# Altium schematic sheet HDMI_to_RGB.SchDoc
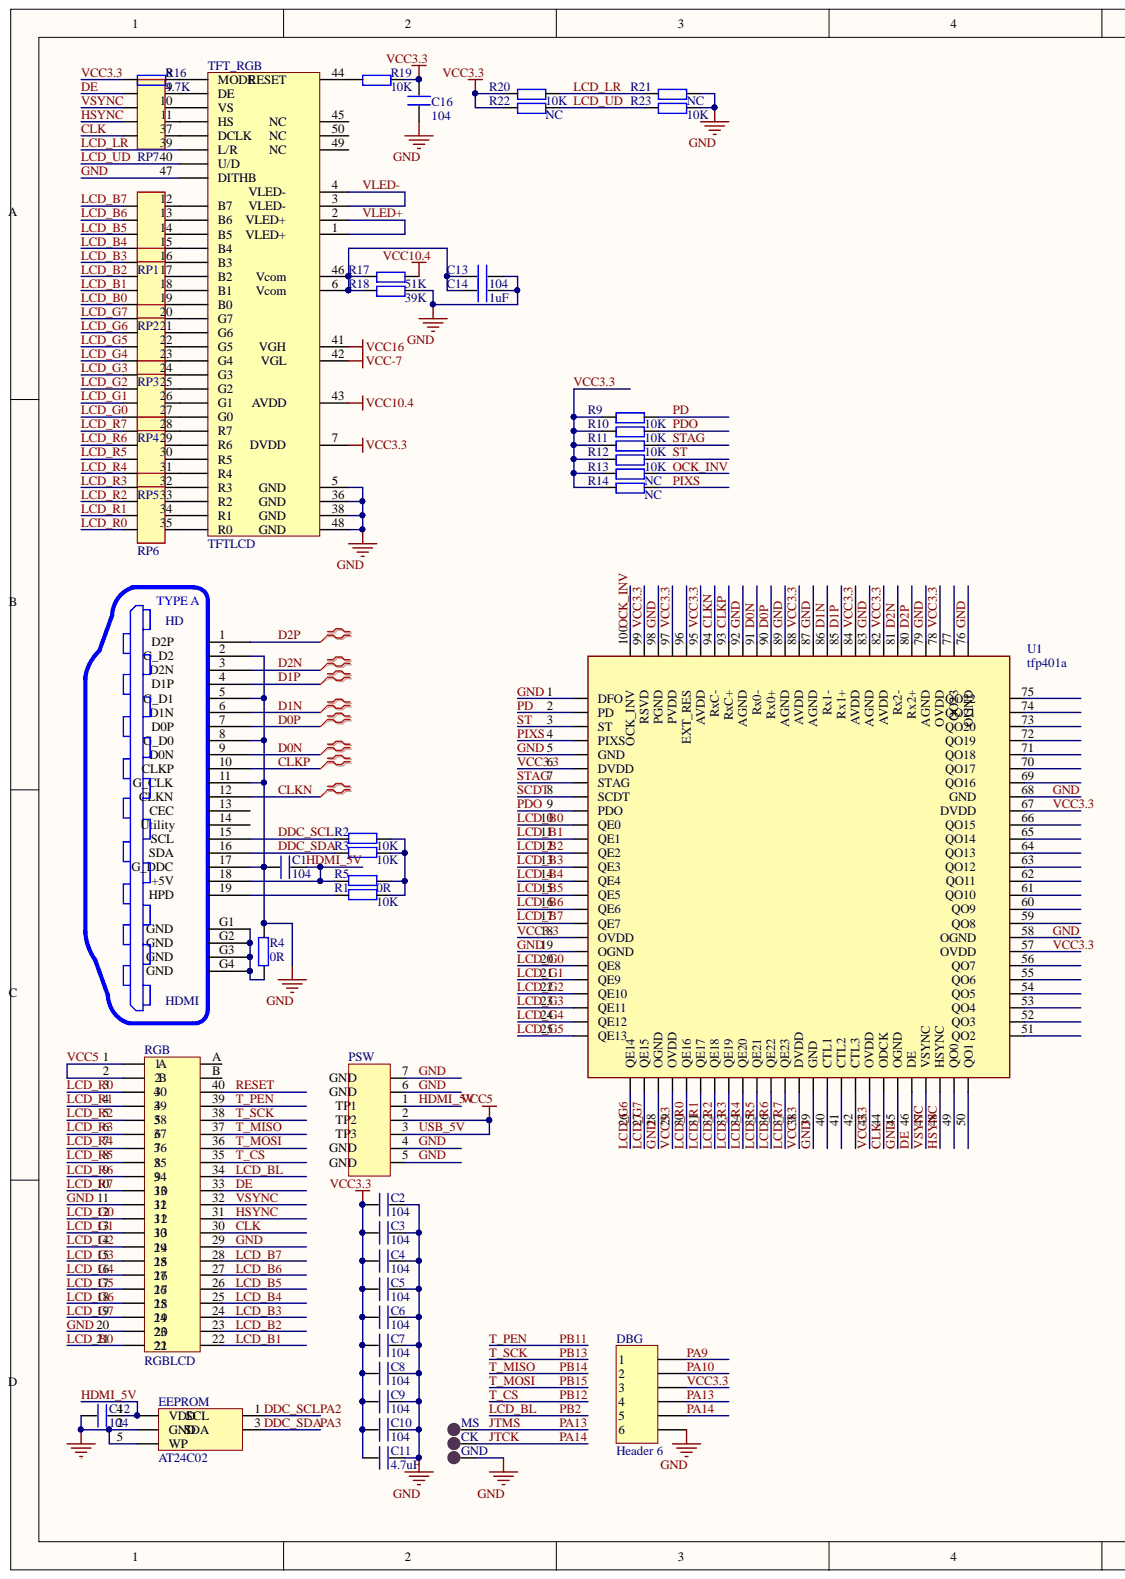
[diagram p0: HDMI_to_RGB.SchDoc, left]
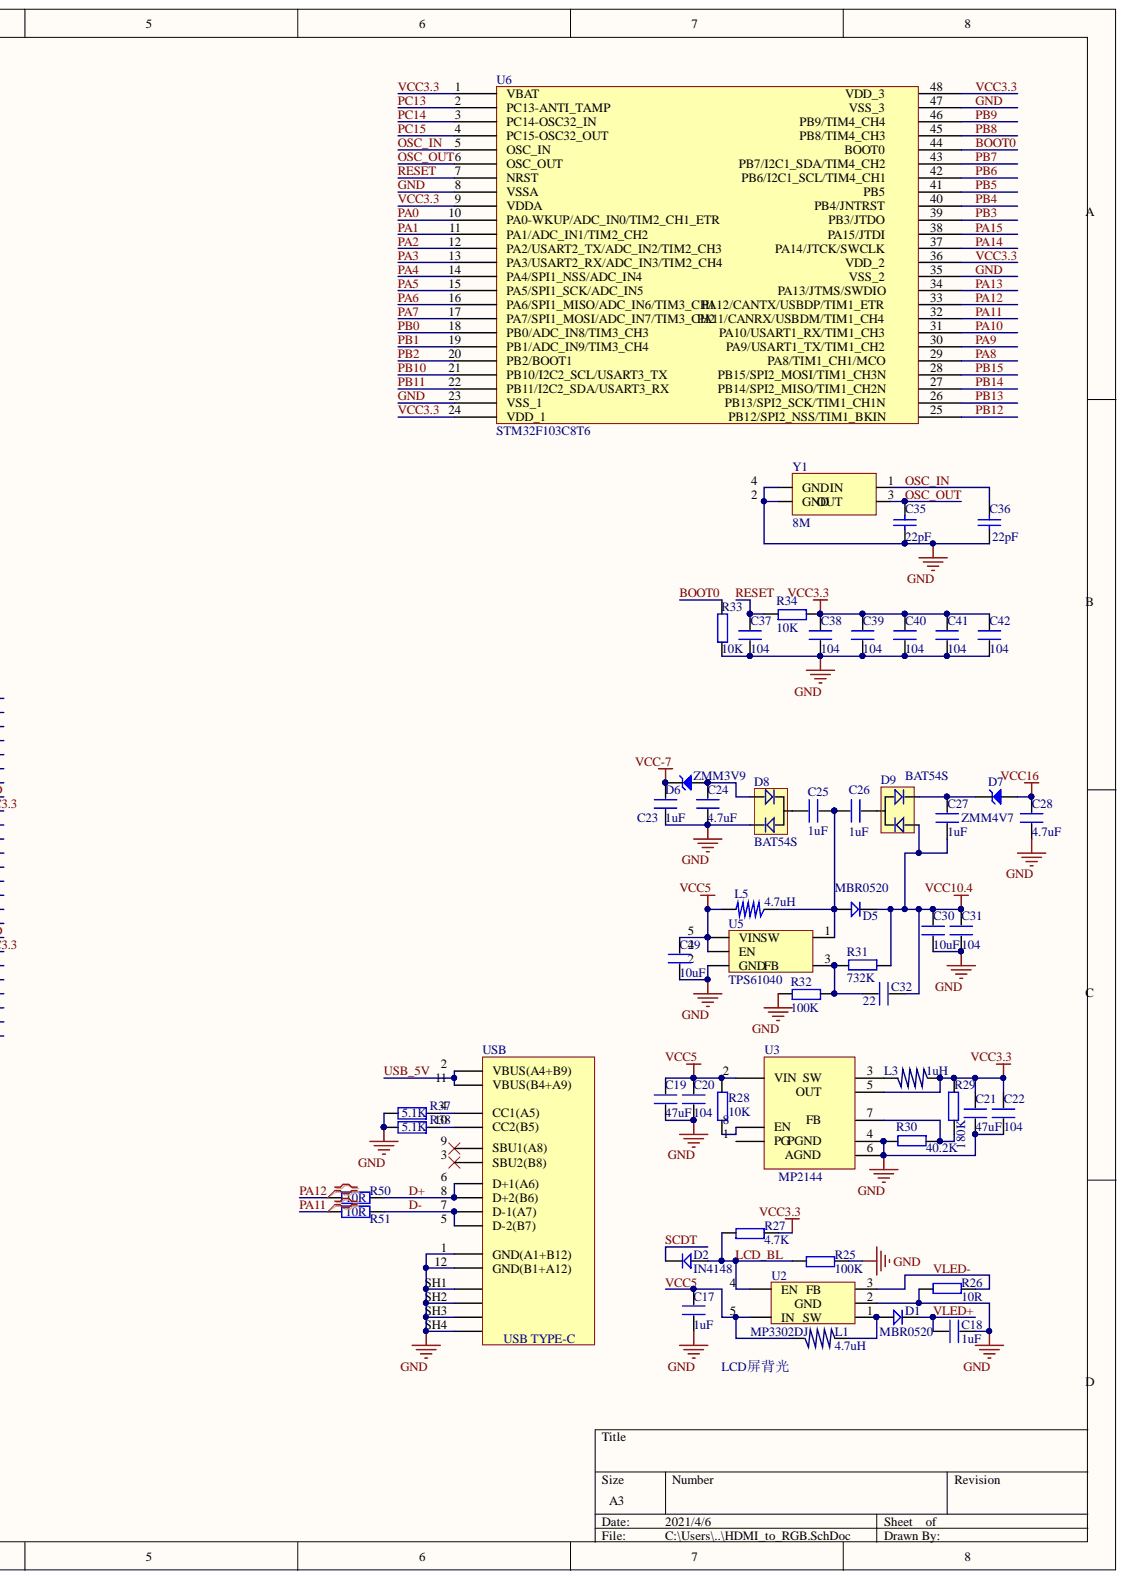
[diagram p1: HDMI_to_RGB.SchDoc, right]

## Parts
C1  104  [C 0603_L]
C2  104  [C 0603_L]
C3  104  [C 0603_L]
C4  104  [C 0603_L]
C5  104  [C 0603_L]
C6  104  [C 0603_L]
C7  104  [C 0603_L]
C8  104  [C 0603_L]
C9  104  [C 0603_L]
C10  104  [C 0603_L]
C11  4.7uF  [C 0603_L]
C12  104  [C 0603_L]
C13  104  [C 0603_L]
C14  1uF  [C 0603_L]
C16  104  [C 0603_L]
C17  1uF  [C 0603_L]
C18  1uF  [C 0603_L]
C19  47uF  [C 0805_L]
C20  104  [C 0603_L]
C21  47uF  [C 0805_L]
C22  104  [C 0603_L]
C23  1uF  [C 0603_L]
C24  4.7uF  [C 0603_L]
C25  1uF  [C 0603_L]
C26  1uF  [C 0603_L]
C27  1uF  [C 0603_L]
C28  4.7uF  [C 0603_L]
C29  10uF  [C 0603_L]
C30  10uF  [C 0603_L]
C31  104  [C 0603_L]
C32  22  [C 0603_L]
C35  22pF  [C 0603_L]
C36  22pF  [C 0603_L]
C37  104  [C 0603_L]
C38  104  [C 0603_L]
C39  104  [C 0603_L]
C40  104  [C 0603_L]
C41  104  [C 0603_L]
C42  104  [C 0603_L]
CK  TEST POINT  [TP-1.5]  (value not drawn)
D1  MBR0520  [SOD-123]
D2  IN4148  [SOD-123]
D5  MBR0520  [SOD-123]
D6  ZMM3V9  [MINI_MELF (LL34)]
D7  ZMM4V7  [MINI_MELF (LL34)]
D8  BAT54S  [SOT23-3L]
D9  BAT54S  [SOT23-3L]
DBG  Header 6  [MX 1.25-LI-6P]
EEPROM  AT24C02  [AT24C02]
GND  TEST POINT  [TP-1.5]  (value not drawn)
HD  HDMI  [HDMI_A]
L1  4.7uH  [NR4010]
L3  1uH  [NR3015]
L5  4.7uH  [NR4010]
MS  TEST POINT  [TP-1.5]  (value not drawn)
PSW  SWITCH  [SWITCH]  (value not drawn)
R1  10K  [R 0603_L]
R2  10K  [R 0603_L]
R3  10K  [R 0603_L]
R4  0R  [R 0603_L]
R5  0R  [R 0603_L]
R9  10K  [R 0603_L]
R10  10K  [R 0603_L]
R11  10K  [R 0603_L]
R12  10K  [R 0603_L]
R13  NC  [R 0603_L]
R14  NC  [R 0603_L]
R16  4.7K  [R 0603_L]
R17  51K  [R 0603_L]
R18  39K  [R 0603_L]
R19  10K  [R 0603_L]
R20  10K  [R 0603_L]
R21  NC  [R 0603_L]
R22  NC  [R 0603_L]
R23  10K  [R 0603_L]
R25  100K  [R 0603_L]
R26  10R  [R 0603_L]
R27  4.7K  [R 0603_L]
R28  10K  [R 0603_L]
R29  180K  [R 0603_L]
R30  40.2K  [R 0603_L]
R31  732K  [R 0603_L]
R32  100K  [R 0603_L]
R33  10K  [R 0603_L]
R34  10K  [R 0603_L]
R37  5.1K  [R 0603_L]
R38  5.1K  [R 0603_L]
R50  10R  [R 0603_L]
R51  10R  [R 0603_L]
RGB  RGBLCD  [FPC0.5 2H-WS-40P]
RP1  33R  [P_pack]  (value not drawn)
RP2  33R  [P_pack]  (value not drawn)
RP3  33R  [P_pack]  (value not drawn)
RP4  33R  [P_pack]  (value not drawn)
RP5  33R  [P_pack]  (value not drawn)
RP6  33R  [P_pack]  (value not drawn)
RP7  33R  [P_pack]  (value not drawn)
TFT_RGB  TFTLCD  [FPC-TOP50]
U1  tfp401a  [LQFP100]
U2  MP3302DJ  [SOT23-5L]
U3  MP2144  [SOT23-8L]
U5  TPS61040  [SOT23-5L]
U6  STM32F103C8T6  [LQFP48 7X7_L]
USB  USB TypeC  [USB Type-C]  (value not drawn)
Y1  8M  [OSC 3225-4P]

## Nets
VCC10.4: C27.1 C30.2 C31.1 C32.1 D5.2 D9.1 R17.2 R31.1 TFT_RGB.43
VCC16: C28.2 D7.1 TFT_RGB.41
VCC-7: C23.1 D6.2 TFT_RGB.42
BOOT0: R33.2 U6.44
CLK: RGB.30 RP7.7 U1.44(ODCK)
CLKN: HD.12 U1.94
CLKP: HD.10 U1.93
D0N: HD.9 U1.91
D0P: HD.7 U1.90
D1N: HD.6 U1.86
D1P: HD.4 U1.85
D2N: HD.3 U1.81
D2P: HD.1 U1.80
D+: R50.1 USB.6(D+1(A6)) USB.8(D+2(B6))
D-: R51.1 USB.5(D-2(B7)) USB.7(D-1(A7))
DDC_SCL: EEPROM.1 HD.15 R2.2 U6.12(PA2/USART2_TX/ADC_IN2/TIM2_CH3)
DDC_SDA: EEPROM.3 HD.16 R3.2 U6.13(PA3/USART2_RX/ADC_IN3/TIM2_CH4)
DE: RGB.33 RP7.1 U1.46(DE)
GND: C1.2 C2.1 C3.1 C4.1 C5.1 C6.1 C7.1 C8.1 C9.1 C10.1 C11.1 C12.1 C13.2 C14.2 C16.1 C17.1 C18.1 C19.1 C20.1 C21.1 C22.1 C23.2 C24.2 C28.1 C29.1 C30.1 C31.2 C35.1 C36.1 C37.1 C38.1 C39.1 C40.1 C41.1 C42.1 D8.2 DBG.6 EEPROM.2(GND) EEPROM.5(WP) GND.1 HD.2 HD.5 HD.8 HD.11 HD.17 PSW.4 PSW.5 PSW.6 PSW.7 R4.1 R18.2 R21.2 R23.2 R25.2 R26.2 R30.2 R32.1 R33.1 R37.1 R38.1 RGB.11 RGB.20 RGB.29 TFT_RGB.5 TFT_RGB.36 TFT_RGB.38 TFT_RGB.47(DITHB) TFT_RGB.48 U1.1(DFO) U1.5(GND) U1.19(OGND) U1.28(OGND) U1.39(GND) U1.45(OGND) U1.58 U1.68 U1.76 U1.79 U1.83 U1.87 U1.89 U1.92 U1.98 U2.2 U3.4 U3.6 U5.2(GND) U6.8(VSSA) U6.23(VSS_1) U6.35 U6.47 USB.1(GND(A1+B12)) USB.12(GND(B1+A12)) USB.SH1(Shield1) USB.SH2(Shield2) USB.SH3(Shield3) USB.SH4(Shield4) Y1.2(GND) Y1.4
HDMI_5V: C1.1 C12.2 EEPROM.4(VDD) HD.18 PSW.1 R5.2
HSYNC: RGB.31 RP7.5 U1.48(HSYNC)
JTCK: CK.1 DBG.5 U6.37
JTMS: DBG.4 MS.1 U6.34
LCD_B0: RGB.21 RP2.7 U1.10(QE0)
LCD_B1: RGB.22 RP2.5 U1.11(QE1)
LCD_B2: RGB.23 RP2.3 U1.12(QE2)
LCD_B3: RGB.24 RP2.1 U1.13(QE3)
LCD_B4: RGB.25 RP1.7 U1.14(QE4)
LCD_B5: RGB.26 RP1.5 U1.15(QE5)
LCD_B6: RGB.27 RP1.3 U1.16(QE6)
LCD_B7: RGB.28 RP1.1 U1.17(QE7)
LCD_BL: D2.1 R25.1 R27.1 RGB.34 U2.4(EN) U6.20(PB2/BOOT1)
LCD_G0: RGB.12 RP4.7 U1.20(QE8)
LCD_G1: RGB.13 RP4.5 U1.21(QE9)
LCD_G2: RGB.14 RP4.3 U1.22(QE10)
LCD_G3: RGB.15 RP4.1 U1.23(QE11)
LCD_G4: RGB.16 RP3.7 U1.24(QE12)
LCD_G5: RGB.17 RP3.5 U1.25(QE13)
LCD_G6: RGB.18 RP3.3 U1.26(QE14)
LCD_G7: RGB.19 RP3.1 U1.27(QE15)
LCD_LR: R20.2 R21.1 TFT_RGB.39(L/R)
LCD_R0: RGB.3 RP6.7 U1.30(QE16)
LCD_R1: RGB.4 RP6.5 U1.31(QE17)
LCD_R2: RGB.5 RP6.3 U1.32(QE18)
LCD_R3: RGB.6 RP6.1 U1.33(QE19)
LCD_R4: RGB.7 RP5.7 U1.34(QE20)
LCD_R5: RGB.8 RP5.5 U1.35(QE21)
LCD_R6: RGB.9 RP5.3 U1.36(QE22)
LCD_R7: RGB.10 RP5.1 U1.37(QE23)
LCD_UD: R22.2 R23.1 TFT_RGB.40(U/D)
OCK_INV: R13.2 U1.100
OSC_IN: C36.2 U6.5(OSC_IN) Y1.1
OSC_OUT: C35.2 U6.6(OSC_OUT) Y1.3
PA0: U6.10(PA0-WKUP/ADC_IN0/TIM2_CH1_ETR)
PA1: U6.11(PA1/ADC_IN1/TIM2_CH2)
PA4: U6.14(PA4/SPI1_NSS/ADC_IN4)
PA5: U6.15(PA5/SPI1_SCK/ADC_IN5)
PA6: U6.16(PA6/SPI1_MISO/ADC_IN6/TIM3_CH1)
PA7: U6.17(PA7/SPI1_MOSI/ADC_IN7/TIM3_CH2)
PA8: U6.29
PA9: DBG.1 U6.30
PA10: DBG.2 U6.31
PA11: R51.2 U6.32
PA12: R50.2 U6.33
PA15: U6.38
PB0: U6.18(PB0/ADC_IN8/TIM3_CH3)
PB1: U6.19(PB1/ADC_IN9/TIM3_CH4)
PB3: U6.39
PB4: U6.40
PB5: U6.41
PB6: U6.42
PB7: U6.43
PB8: U6.45
PB9: U6.46
PB10: U6.21(PB10/I2C2_SCL/USART3_TX)
PB11: RGB.39 U6.22(PB11/I2C2_SDA/USART3_RX)
PB12: RGB.35 U6.25
PB13: RGB.38 U6.26
PB14: RGB.37 U6.27
PB15: RGB.36 U6.28
PC13: U6.2(PC13-ANTI_TAMP)
PC14: U6.3(PC14-OSC32_IN)
PC15: U6.4(PC15-OSC32_OUT)
PD: R9.2 U1.2(PD)
PDO: R10.2 U1.9(PDO)
PIXS: R14.2 U1.4(PIXS)
RESET: C37.2 R34.1 RGB.40 U6.7(NRST)
SCDT: D2.2 U1.8(SCDT)
ST: R12.2 U1.3(ST)
STAG: R11.2 U1.7(STAG)
USB_5V: C17.2 C19.2 C20.2 C29.2 L1.1 L5.2 PSW.2 PSW.3 R28.2 RGB.1 RGB.2 U2.5(IN) U3.2(VIN) U5.4(EN) U5.5(VIN_SW) USB.2(VBUS(A4+B9)) USB.11(VBUS(B4+A9))
VCC3.3: C2.2 C3.2 C4.2 C5.2 C6.2 C7.2 C8.2 C9.2 C10.2 C11.2 C16.2 C21.2 C22.2 C38.2 C39.2 C40.2 C41.2 C42.2 DBG.3 L3.1 R9.1 R10.1 R11.1 R12.1 R13.1 R14.1 R16.1 R19.2 R20.1 R22.1 R27.2 R29.1 R34.2 TFT_RGB.7 U1.6(DVDD) U1.18(OVDD) U1.29(OVDD) U1.38(DVDD) U1.43(OVDD) U1.57 U1.67 U1.78 U1.82 U1.84 U1.88 U1.95 U1.97 U1.99 U3.5 U6.1(VBAT) U6.9(VDDA) U6.24(VDD_1) U6.36 U6.48
VLED+: C18.2 D1.2 TFT_RGB.1 TFT_RGB.2
VLED-: R26.1 TFT_RGB.3 TFT_RGB.4 U2.3
VSYNC: RGB.32 RP7.3 U1.47(VSYNC)
NetC13_1: C13.1 C14.1 R17.1 R18.1 TFT_RGB.6 TFT_RGB.46
NetC24_1: C24.1 D6.1 D8.1
NetC25_1: C25.1 D8.3
NetC25_2: C25.2 C26.1 D5.1 L5.1 U5.1
NetC26_2: C26.2 D9.3
NetC27_2: C27.2 D7.2 D9.2
NetC32_2: C32.2 R31.2 R32.2 U5.3
NetD1_1: D1.1 L1.2 U2.1
NetHD_19: HD.19 R1.2
NetHD_G1: HD.G1 HD.G2 HD.G3 HD.G4 R4.2
NetL3_2: L3.2 U3.3
NetR1_1: R1.1 R2.1 R3.1 R5.1
NetR16_2: R16.2 TFT_RGB.8(MODE)
NetR19_1: R19.1 TFT_RGB.44
NetR28_1: R28.1 U3.8(EN)
NetR29_2: R29.2 R30.1 U3.7
NetR37_2: R37.2 USB.4(CC1(A5))
NetR38_2: R38.2 USB.10(CC2(B5))
NetRP1_2: RP1.2 TFT_RGB.12(B7)
NetRP1_4: RP1.4 TFT_RGB.13(B6)
NetRP1_6: RP1.6 TFT_RGB.14(B5)
NetRP1_8: RP1.8 TFT_RGB.15(B4)
NetRP2_2: RP2.2 TFT_RGB.16(B3)
NetRP2_4: RP2.4 TFT_RGB.17(B2)
NetRP2_6: RP2.6 TFT_RGB.18(B1)
NetRP2_8: RP2.8 TFT_RGB.19(B0)
NetRP3_2: RP3.2 TFT_RGB.20(G7)
NetRP3_4: RP3.4 TFT_RGB.21(G6)
NetRP3_6: RP3.6 TFT_RGB.22(G5)
NetRP3_8: RP3.8 TFT_RGB.23(G4)
NetRP4_2: RP4.2 TFT_RGB.24(G3)
NetRP4_4: RP4.4 TFT_RGB.25(G2)
NetRP4_6: RP4.6 TFT_RGB.26(G1)
NetRP4_8: RP4.8 TFT_RGB.27(G0)
NetRP5_2: RP5.2 TFT_RGB.28(R7)
NetRP5_4: RP5.4 TFT_RGB.29(R6)
NetRP5_6: RP5.6 TFT_RGB.30(R5)
NetRP5_8: RP5.8 TFT_RGB.31(R4)
NetRP6_2: RP6.2 TFT_RGB.32(R3)
NetRP6_4: RP6.4 TFT_RGB.33(R2)
NetRP6_6: RP6.6 TFT_RGB.34(R1)
NetRP6_8: RP6.8 TFT_RGB.35(R0)
NetRP7_2: RP7.2 TFT_RGB.9(DE)
NetRP7_4: RP7.4 TFT_RGB.10(VS)
NetRP7_6: RP7.6 TFT_RGB.11(HS)
NetRP7_8: RP7.8 TFT_RGB.37(DCLK)

Not connected: HD 13 14; RGB A B; TFT_RGB 45 49 50; U1 40(CTL1) 41(CTL2) 42(CTL3) 49(QO0) 50(QO1) 51 52 53 54 55 56 59 60 61 62 63 64 65 66 69 70 71 72 73 74 75 77 96; U3 1(PG); USB 3(SBU2(B8)) 9(SBU1(A8))

## Library data of the parts
C1-C14 C16-C32 C37-C42 = C: Component Kind: Standard
  C1-C14 C16 C19-C22 C37-C42: Library Name: WarShip V3 STM32103封装库.IntLib
  C17 C18: Library Name: 阿波罗STM32F4&F7底板封装库.IntLib
  C23-C32: Library Name: ALIENTEK_COMPONENT.IntLib
C35 C36 = CAP: Component Kind: Standard; Library Name: L476.SchLib
CK GND MS = TEST-POINT: 测试点; Component Kind: Standard; Library Name: STM32F767核心板封装库.IntLib
D1 D2 D5 = D: 1N4148; Component Kind: Standard
  D5: Library Name: ALIENTEK_COMPONENT.IntLib
  D1 D2: Library Name: ATK-4.3' TFTLCD电容触摸屏模块_V1.2.IntLib
D6 D7 = ZMM3V6: Component Kind: Standard; Description: ZMM3V6; Library Name: ALIENTEK_COMPONENT.IntLib
D8 D9 = BAT54S: 双肖特基二极管; Component Kind: Standard; Library Name: ALIENTEK_COMPONENT.IntLib
DBG = Header 6: Header, 6-Pin; Component Kind: Standard; LatestRevisionDate: 17-Jul-2002; LatestRevisionNote: Re-released for DXP Platform.; Library Name: Miscellaneous Connectors.IntLib; Signal Integrity: Connector; Publisher: Altium Limited
L1 L3 = L: Component Kind: Standard
  L3: Library Name: MiniFly .IntLib
  L1: Library Name: ATK-4.3' TFTLCD电容触摸屏模块_V1.2.IntLib
L5 = L_SOP: Component Kind: Standard; Library Name: ALIENTEK_COMPONENT.IntLib
R1-R5 R9-R14 R16-R23 R25-R34 = R: Component Kind: Standard
  R1-R5 R9-R14 R16-R23 R27 R33 R34: Library Name: WarShip V3 STM32103封装库.IntLib
  R25 R26 R28: Library Name: 阿波罗STM32F4&F7底板封装库.IntLib
  R29 R30: Library Name: 电阻-电容-电感.SchLib
  R31 R32: Library Name: ALIENTEK_COMPONENT.IntLib
R37 R38 R50 R51 = R: 贴片电阻; 版权归属: 源创客; BOM名称: 贴片电阻; Component Kind: Standard; 学习资源: www.ooocke.com
  R50 R51: Library Name: 电阻-电容-电感.SchLib
  R37 R38: Library Name: Lycreturn.IntLib
RGB = FPC-40: FPC-40P-0.5mm; Component Kind: Standard; Library Name: STM32F429核心板封装库.IntLib
RP1-RP7 = R_pack: Component Kind: Standard; Library Name: stm32f767igt6simple.SchLib
TFT_RGB = AT070TN92: 7' TFTLCD; Component Kind: Standard; Library Name: ATK-7016&7084 7寸RGB屏模块封装库.IntLib
U2 = MP3302: LCD背光IC; Component Kind: Standard; Library Name: ATK-4.3' TFTLCD电容触摸屏模块_V1.2.IntLib
U5 = TPS61040: DC DC Step Up IC; Component Kind: Standard; Library Name: ALIENTEK_COMPONENT.IntLib
U6 = U-STM32F103C8T6: Component Kind: Standard; Library Name: Pandora_STM32L4_Board_V2.4.IntLib
USB = USB Type-C: 12P TypeC 母座; Component Kind: Standard; Library Name: 接口类.SchLib
Y1 = XTAL-4P: 4脚无源晶振; BOM名称: 4脚晶振; 版权归属: 源创客; Component Kind: Standard; Library Name: 保险丝-晶振.SchLib; 学习资源: www.ooocke.com
EEPROM = AT24C02: Component Kind: Standard; Library Name: stm32f767igt6simple.SchLib
HD = HDMI: Component Kind: Standard; Library Name: stm32f767igt6simple.SchLib
PSW = SWITCH: Component Kind: Standard; Library Name: TP4056.SchLib
U1 = tfp401a: Component Kind: Standard; Library Name: stm32f767igt6simple.SchLib
U3 = MP2144: Component Kind: Standard; Library Name: LDO和DCDC.SchLib
